# Altium schematic sheet [06] - AUDIO, SERVO.SchDoc("[06] - AUDIO, SERVO")
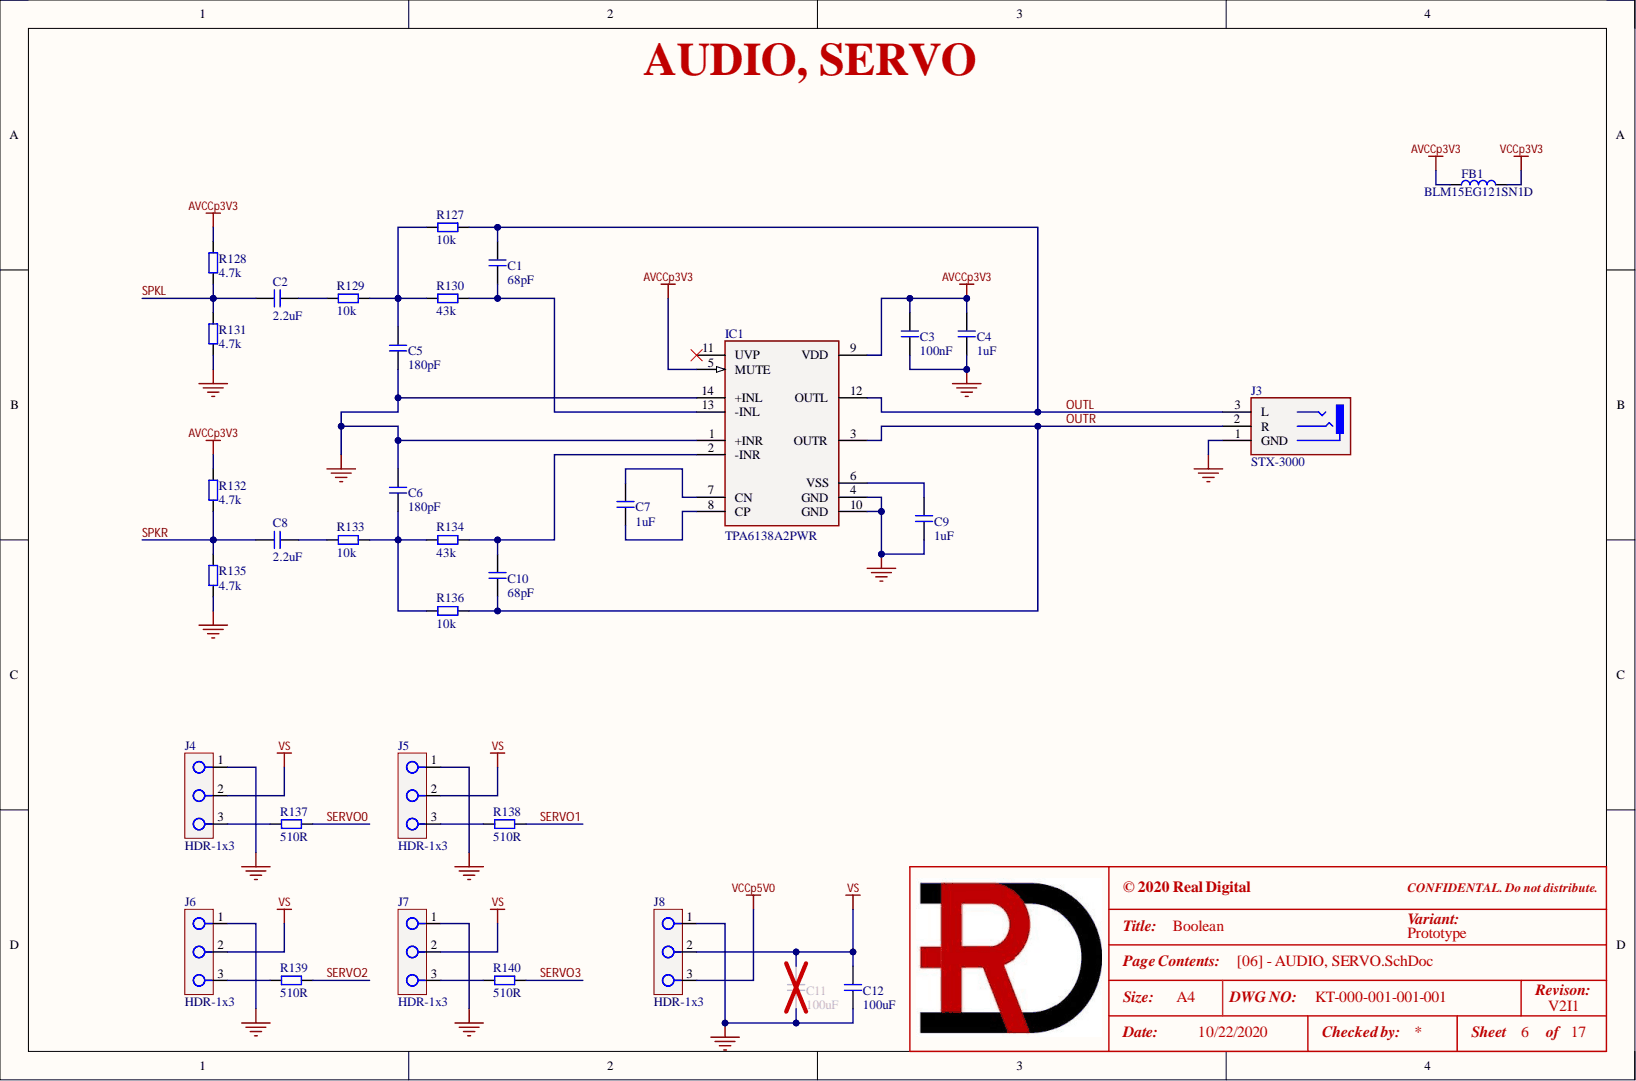
[diagram p0: [06] - AUDIO, SERVO.SchDoc]

## Parts
C1  68pF  [C0603]
C2  2.2uF  [C0603]
C3  100nF  [C0402]
C4  1uF  [C0603]
C5  180pF  [C0603]
C6  180pF  [C0603]
C7  1uF  [C0603]
C8  2.2uF  [C0603]
C9  1uF  [C0603]
C10  68pF  [C0603]
C11  100uF  [C1210]
C12  100uF  [C1210]
FB1  BLM15EG121SN1D  [BLM15]
IC1  TPA6138A2PWR  [TSSOP14]
J3  STX-3000  [STX-3000]
J4  HDR-1x3  [HDR1X3]
J5  HDR-1x3  [HDR1X3]
J6  HDR-1x3  [HDR1X3]
J7  HDR-1x3  [HDR1X3]
J8  HDR-1x3  [HDR1X3]
R127  10k  [R0402]
R128  4.7k  [R0402]
R129  10k  [R0402]
R130  43k  [R0402]
R131  4.7k  [R0402]
R132  4.7k  [R0402]
R133  10k  [R0402]
R134  43k  [R0402]
R135  4.7k  [R0402]
R136  10k  [R0402]
R137  510R  [R0402]
R138  510R  [R0402]
R139  510R  [R0402]
R140  510R  [R0402]

## Nets
AVCCp3V3: C3.2 C4.2 FB1.1 IC1.5(MUTE) IC1.9(VDD) R128.2 R132.2
GND: C3.1 C4.1 C5.1 C6.2 C9.1 C11.1 C12.1 IC1.1(+INR) IC1.4(GND) IC1.10(GND) IC1.14(+INL) J3.1 J4.1 J5.1 J6.1 J7.1 J8.1 R131.1 R135.1
VCCp3V3: FB1.2
VCCp5V0: J8.3
VS: C11.2 C12.2 J4.2 J5.2 J6.2 J7.2 J8.2
OUTL: C1.2 IC1.12(OUTL) J3.3 R127.2
OUTR: C10.2 IC1.3(OUTR) J3.2 R136.2
SERVO0: R137.2
SERVO1: R138.2
SERVO2: R139.2
SERVO3: R140.2
SPKL: C2.1 R128.1 R131.2
SPKR: C8.1 R132.1 R135.2
NetC1_1: C1.1 IC1.13(-INL) R130.2
NetC2_2: C2.2 R129.1
NetC5_2: C5.2 R127.1 R129.2 R130.1
NetC6_1: C6.1 R133.2 R134.1 R136.1
NetC7_1: C7.1 IC1.8(CP)
NetC7_2: C7.2 IC1.7(CN)
NetC8_2: C8.2 R133.1
NetC9_2: C9.2 IC1.6(VSS)
NetC10_1: C10.1 IC1.2(-INR) R134.2
NetJ4_3: J4.3 R137.1
NetJ5_3: J5.3 R138.1
NetJ6_3: J6.3 R139.1
NetJ7_3: J7.3 R140.1

Not connected: IC1 11(UVP)

## Library data of the parts
C1 C10 = CC0603JRNPO9BN680: CAP CER 68PF 50V C0G/NPO 0603; Manufacturer 1: Yageo; .Checked_PCB: AC; .Creator: RV; C P/N: RD-111-0001; LoadStatus: Load; Temperature Coefficient: C0G, NP0; Tolerance: 5%; Category: Capacitors; Component Kind: Standard; Library Name: KT-RealDigital.IntLib; Manufacturer 1 Part Number: CC0603JRNPO9BN680; Voltage - Rated: 50V; .Checked_SCH: AC
C2 C8 = GRM188R61E225KA12D: CAP CER 2.2uF 25V X5R 0603; Manufacturer 1: Murata Electronics; .Checked_PCB: AC; .Creator: RV; C P/N: 111-0404; LoadStatus: Load; Tolerance: 10%; Category: Capacitors; Component Kind: Standard; Library Name: KT-RealDigital.IntLib; Manufacturer 1 Part Number: GRM188R61E225KA12D; Temperature Coefficient: X5R; Voltage - Rated: 25V; .Checked_SCH: AC
C3 = GRM155R71E104KE14J: CAP CER 0.1uF 25V X7R 0402; Manufacturer 1: Murata Electronics; .Checked_PCB: AC; .Creator: RV; C P/N: RD-111-0006; LoadStatus: Load; Tolerance: 10%; Category: Capacitors; Component Kind: Standard; Library Name: KT-RealDigital.IntLib; Manufacturer 1 Part Number: GRM155R71E104KE14J; Temperature Coefficient: X7R; Voltage - Rated: 25V; .Checked_SCH: AC
C4 C7 C9 = GCJ188R71E105KA01D: CAP CER 1uF 25V X7R 0603; Manufacturer 1: Murata Electronics; .Checked_PCB: AC; .Creator: RV; C P/N: 111-0602; LoadStatus: Load; Tolerance: 10%; Category: Capacitors; Component Kind: Standard; Library Name: KT-RealDigital.IntLib; Manufacturer 1 Part Number: GCJ188R71E105KA01D; Temperature Coefficient: X7R; Voltage - Rated: 25V; .Checked_SCH: AC
C5 C6 = CC0603JRNPO9BN181: CAP CER 180PF 50V C0G/NPO 0603; Manufacturer 1: Yageo; .Checked_PCB: AC; .Creator: RV; C P/N: RD-111-0009; LoadStatus: Load; Temperature Coefficient: C0G, NP0; Tolerance: 5%; Category: Capacitors; Component Kind: Standard; Library Name: KT-RealDigital.IntLib; Manufacturer 1 Part Number: CC0603JRNPO9BN181; Voltage - Rated: 50V; .Checked_SCH: AC
C11 C12 = GRM32ER61A107ME20L: CAP CER 100UF 10V X5R 1210; Manufacturer 1: Murata Electronics; .Checked_PCB: AC; .Creator: RV; C P/N: RD-111-0004; LoadStatus: Load; Tolerance: 20%; Category: Capacitors; Component Kind: Standard; Library Name: KT-RealDigital.IntLib; Manufacturer 1 Part Number: GRM32ER61A107ME20L; Temperature Coefficient: X5R; Voltage - Rated: 10V; .Checked_SCH: AC
FB1 = BLM15EG121SN1D: FERRITE BEAD 120 OHM 0402 1LN; Manufacturer 1: Murata Electronics; .Checked_PCB: AC; .Creator: RV; C P/N: 131-0493; LoadStatus: Load; Category: Ferrite Beads; Component Kind: Standard; Library Name: KT-RealDigital.IntLib; Manufacturer 1 Part Number: BLM15EG121SN1D; .Checked_SCH: AC
IC1 = TPA6138A2PWR: IC AMP CLSS AB STER 40MW 14TSSOP; Manufacturer 1: Texas Instruments; .Creator: RV; C P/N: CML-126-0051; LoadStatus: Load; Category: IC; Component Kind: Standard; Library Name: KT-RealDigital.IntLib; Manufacturer 1 Part Number: TPA6138A2PWR
J3 = STX-3000: 3.50mm (0.141", 1/8", Mini Plug) - Headphone Phone Jack Stereo (3 Conductor, TRS) Connector Kinked Pin; Manufacturer 1: Kycon, Inc.; Manufacturer 2 Part Number: PJD-035-27SDB; .Checked_PCB: AC; .Creator: RV; C P/N: 163-0000; LoadStatus: Load; Category: Connector; Component Kind: Standard; Library Name: KT-RealDigital.IntLib; Manufacturer 1 Part Number: STX-3000; Manufacturer 2: JKCR Electronics Co., Ltd.; .Checked_SCH: AC
J4-J8 = HDR-1x3: Header 2.54mm, 1x3; Manufacturer 1: Centronic Precision Electronic; Manufacturer 1 Part Number: H6-80-03; .Checked_PCB: AC; .Creator: RV; C P/N: 163-0035; LoadStatus: Load; Category: Header; Component Kind: Standard; Library Name: KT-RealDigital.IntLib; .Checked_SCH: AC
R127 R129 R133 R136 = RT0402BRB0710KL: RES SMD 10K OHM 0.1% 1/16W 0402; Manufacturer 1: Yageo; .Checked_PCB: AC; .Creator: RV; C P/N: 101-0862; LoadStatus: Load; Category: Resistors; Component Kind: Standard; Library Name: KT-RealDigital.IntLib; Manufacturer 1 Part Number: RT0402BRB0710KL; Tolerance: 0.1%; .Checked_SCH: AC
R128 R131 R132 R135 = RC0402FR-074K7P: RES SMD 4.7K OHM 1% 1/16W 0402; Manufacturer 1: Yageo; .Checked_PCB: AC; .Creator: RV; C P/N: 101-0129; LoadStatus: Load; Category: Resistors; Component Kind: Standard; Library Name: KT-RealDigital.IntLib; Manufacturer 1 Part Number: RC0402FR-074K7P; Tolerance: 1%; .Checked_SCH: AC
R130 R134 = RC0402FR-0743KL: RES SMD 43K OHM 1% 1/16W 0402; Manufacturer 1: Yageo; .Checked_PCB: AC; .Creator: RV; C P/N: RD-101-0001; LoadStatus: Load; Category: Resistors; Component Kind: Standard; Library Name: KT-RealDigital.IntLib; Manufacturer 1 Part Number: RC0402FR-0743KL; Tolerance: 1%; .Checked_SCH: AC
R137-R140 = RC0402JR-0751RL: RES SMD 51 OHM 5% 1/16W 0402; Manufacturer 1: Yageo; .Checked_PCB: AC; .Creator: RV; C P/N: 101-0040; LoadStatus: Load; Category: Resistors; Component Kind: Standard; Library Name: KT-RealDigital.IntLib; Manufacturer 1 Part Number: RC0402JR-0751RL; Tolerance: 5%; .Checked_SCH: AC
